# Altium schematic sheet IF.SchDoc(IF)
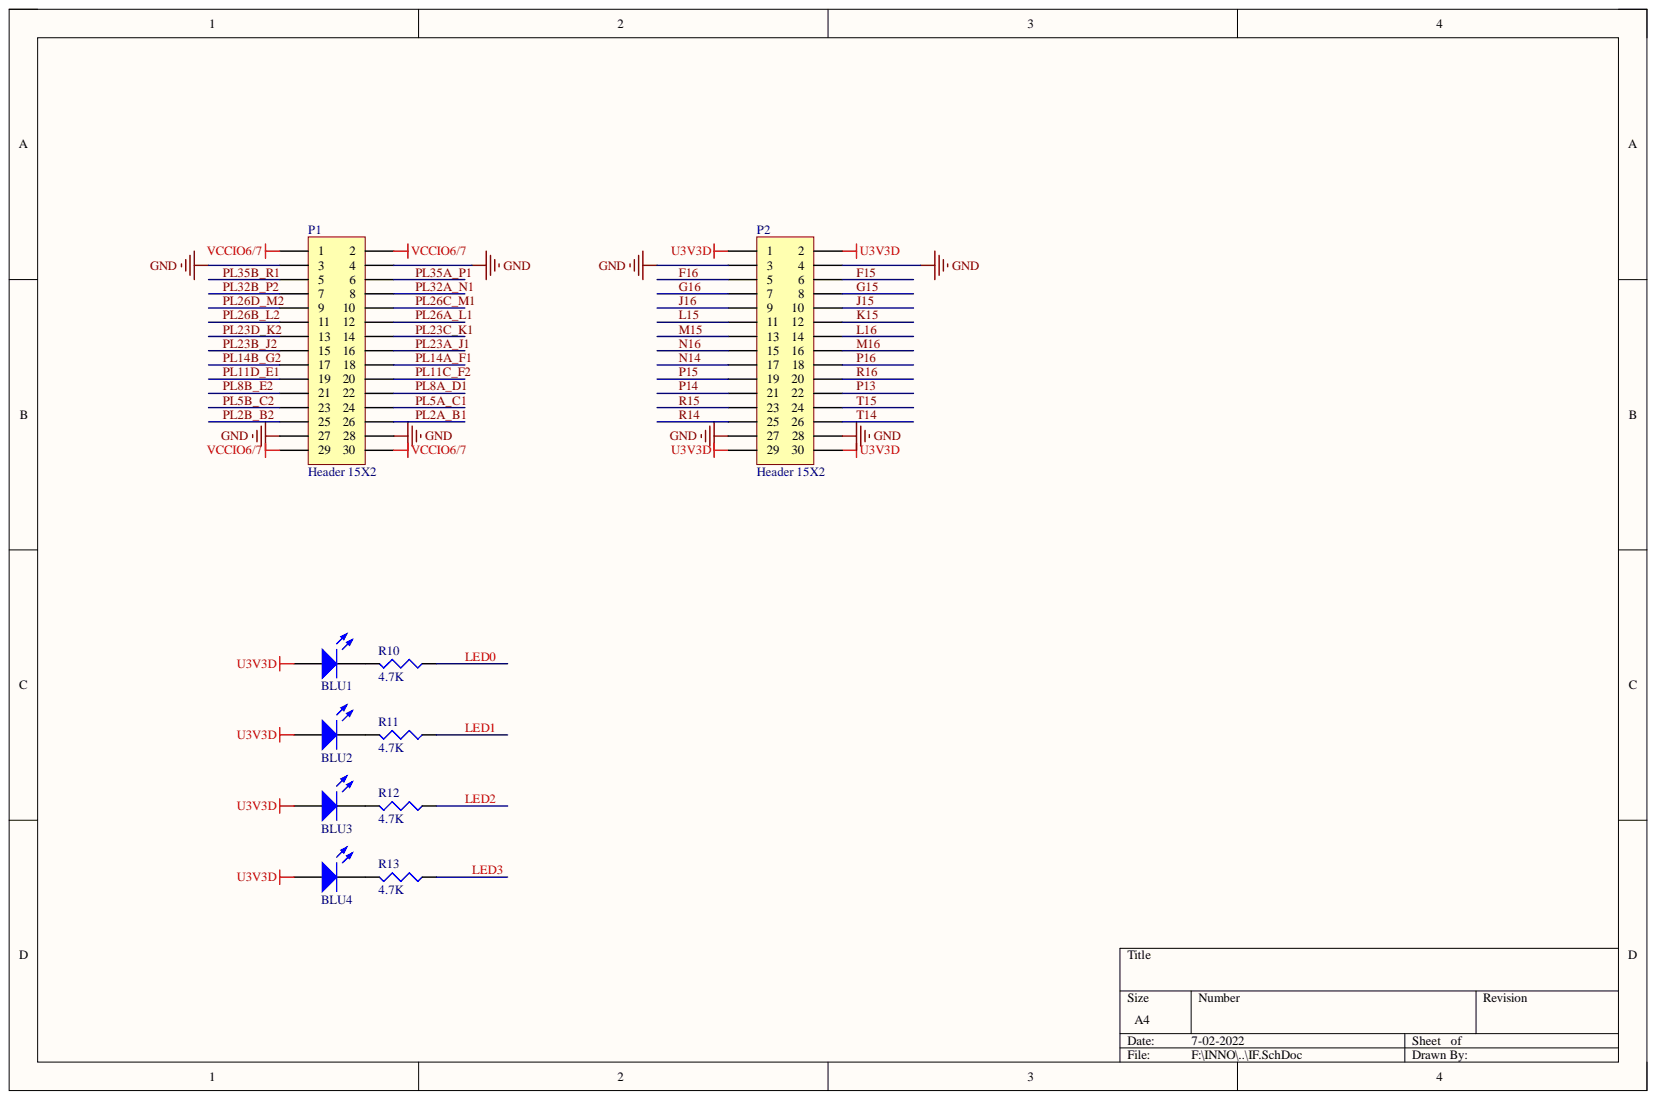
[diagram p0: IF.SchDoc]

## Parts
BLU1  LED  [0805-LED]  (value not drawn)
BLU2  LED  [0805-LED]  (value not drawn)
BLU3  LED  [0805-LED]  (value not drawn)
BLU4  LED  [0805-LED]  (value not drawn)
P1  Header 15X2  [2x15-2.54]
P2  Header 15X2  [2x15-2.54]
R10  4.7K  [0402-R]
R11  4.7K  [0402-R]
R12  4.7K  [0402-R]
R13  4.7K  [0402-R]

## Nets
GND: P1.3 P1.4 P1.27 P1.28 P2.3 P2.4 P2.27 P2.28
U3V3D: BLU1.1 BLU2.1 BLU3.1 BLU4.1 P2.1 P2.2 P2.29 P2.30
VCCIO6/7: P1.1 P1.2 P1.29 P1.30
F15: P2.6
F16: P2.5
G15: P2.8
G16: P2.7
J15: P2.10
J16: P2.9
K15: P2.12
L15: P2.11
L16: P2.14
LED0: R10.1
LED1: R11.1
LED2: R12.1
LED3: R13.1
M15: P2.13
M16: P2.16
N14: P2.17
N16: P2.15
P13: P2.22
P14: P2.21
P15: P2.19
P16: P2.18
PL2A_B1: P1.26
PL2B_B2: P1.25
PL5A_C1: P1.24
PL5B_C2: P1.23
PL8A_D1: P1.22
PL8B_E2: P1.21
PL11C_F2: P1.20
PL11D_E1: P1.19
PL14A_F1: P1.18
PL14B_G2: P1.17
PL23A_J1: P1.16
PL23B_J2: P1.15
PL23C_K1: P1.14
PL23D_K2: P1.13
PL26A_L1: P1.12
PL26B_L2: P1.11
PL26C_M1: P1.10
PL26D_M2: P1.9
PL32A_N1: P1.8
PL32B_P2: P1.7
PL35A_P1: P1.6
PL35B_R1: P1.5
R14: P2.25
R15: P2.23
R16: P2.20
T14: P2.26
T15: P2.24
NetBLU1_2: BLU1.2 R10.2
NetBLU2_2: BLU2.2 R11.2
NetBLU3_2: BLU3.2 R12.2
NetBLU4_2: BLU4.2 R13.2

## Library data of the parts
BLU1-BLU4 = LED: LED灯; Component Kind: Standard; Library Name: ctr_prj.SchLib
P1 P2 = Header 15X2: Header, 15-Pin, Dual row; Component Kind: Standard; LatestRevisionDate: 17-Jul-2002; LatestRevisionNote: Re-released for DXP Platform.; Library Name: Miscellaneous Connectors.IntLib; Signal Integrity: Connector; Publisher: Altium Limited
R10-R13 = RES: 电阻; Component Kind: Standard; Library Name: YuanQi.SchLib
